# Altium schematic sheet DDR3.SchDoc
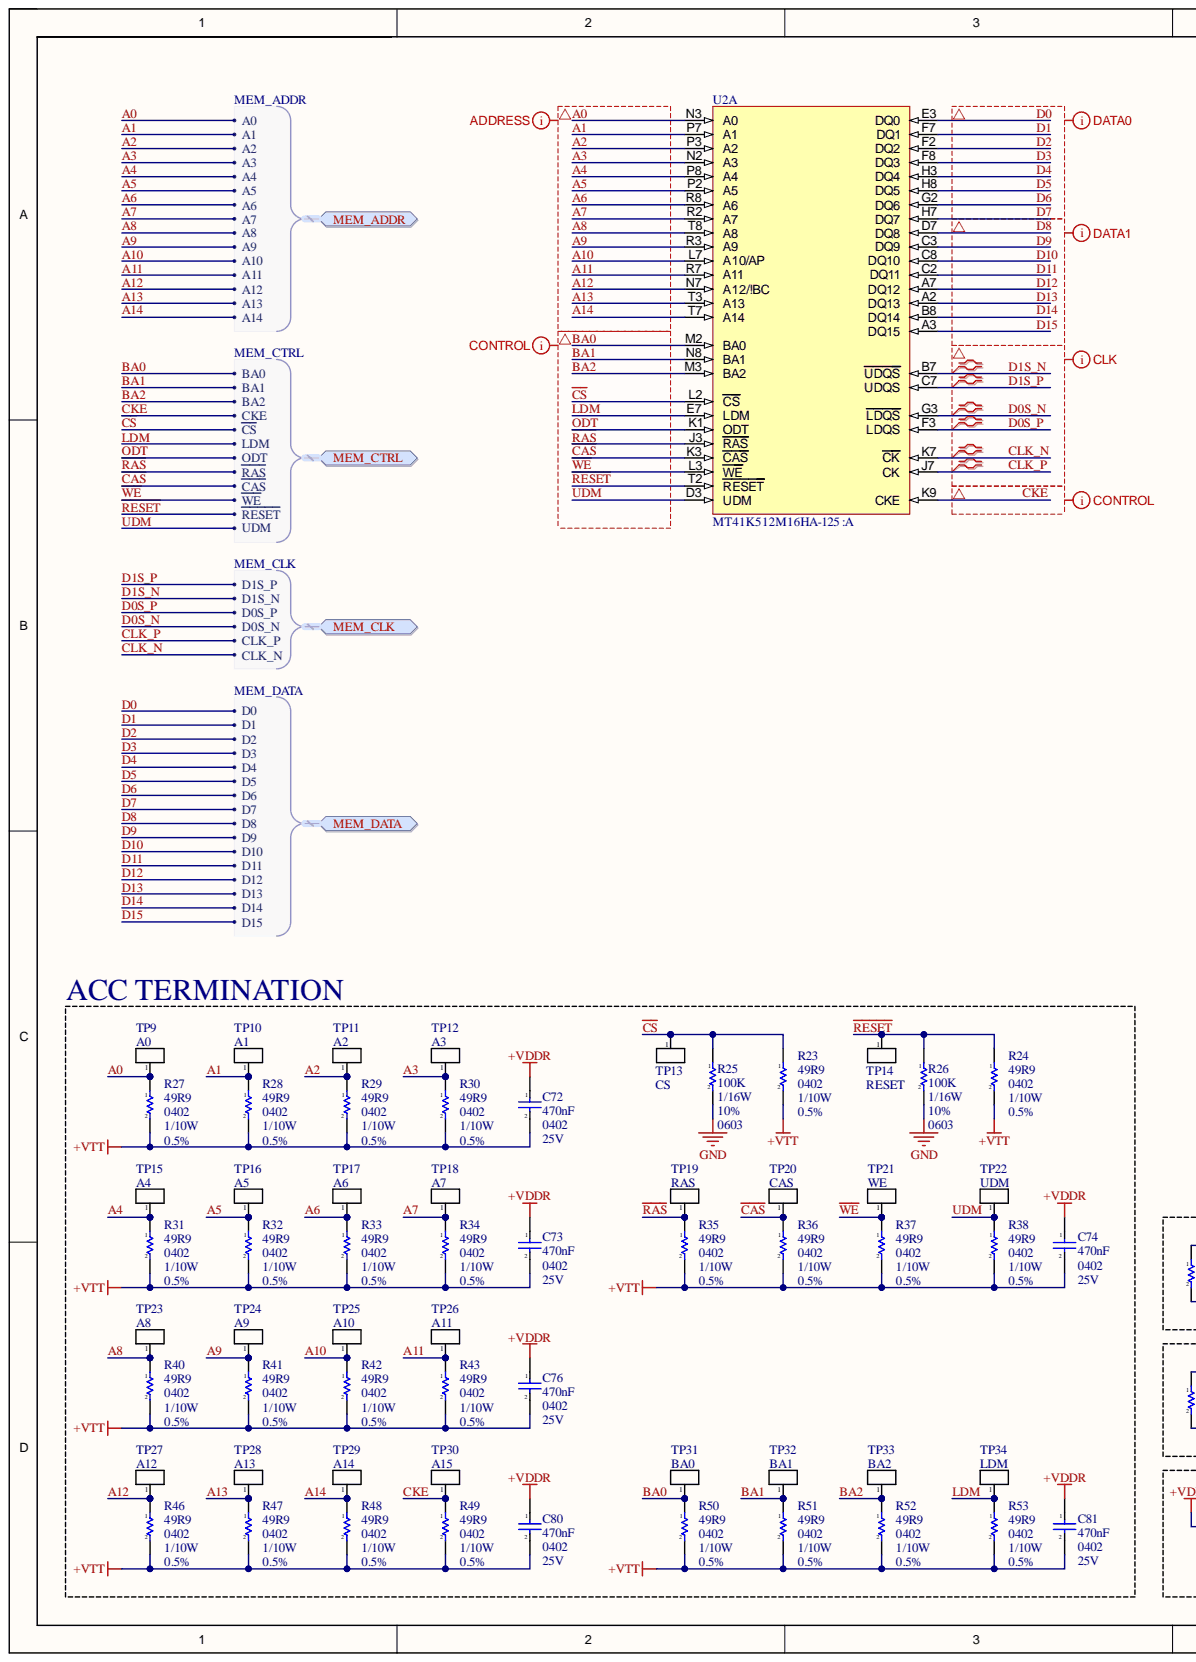
[diagram p0: DDR3.SchDoc, left]
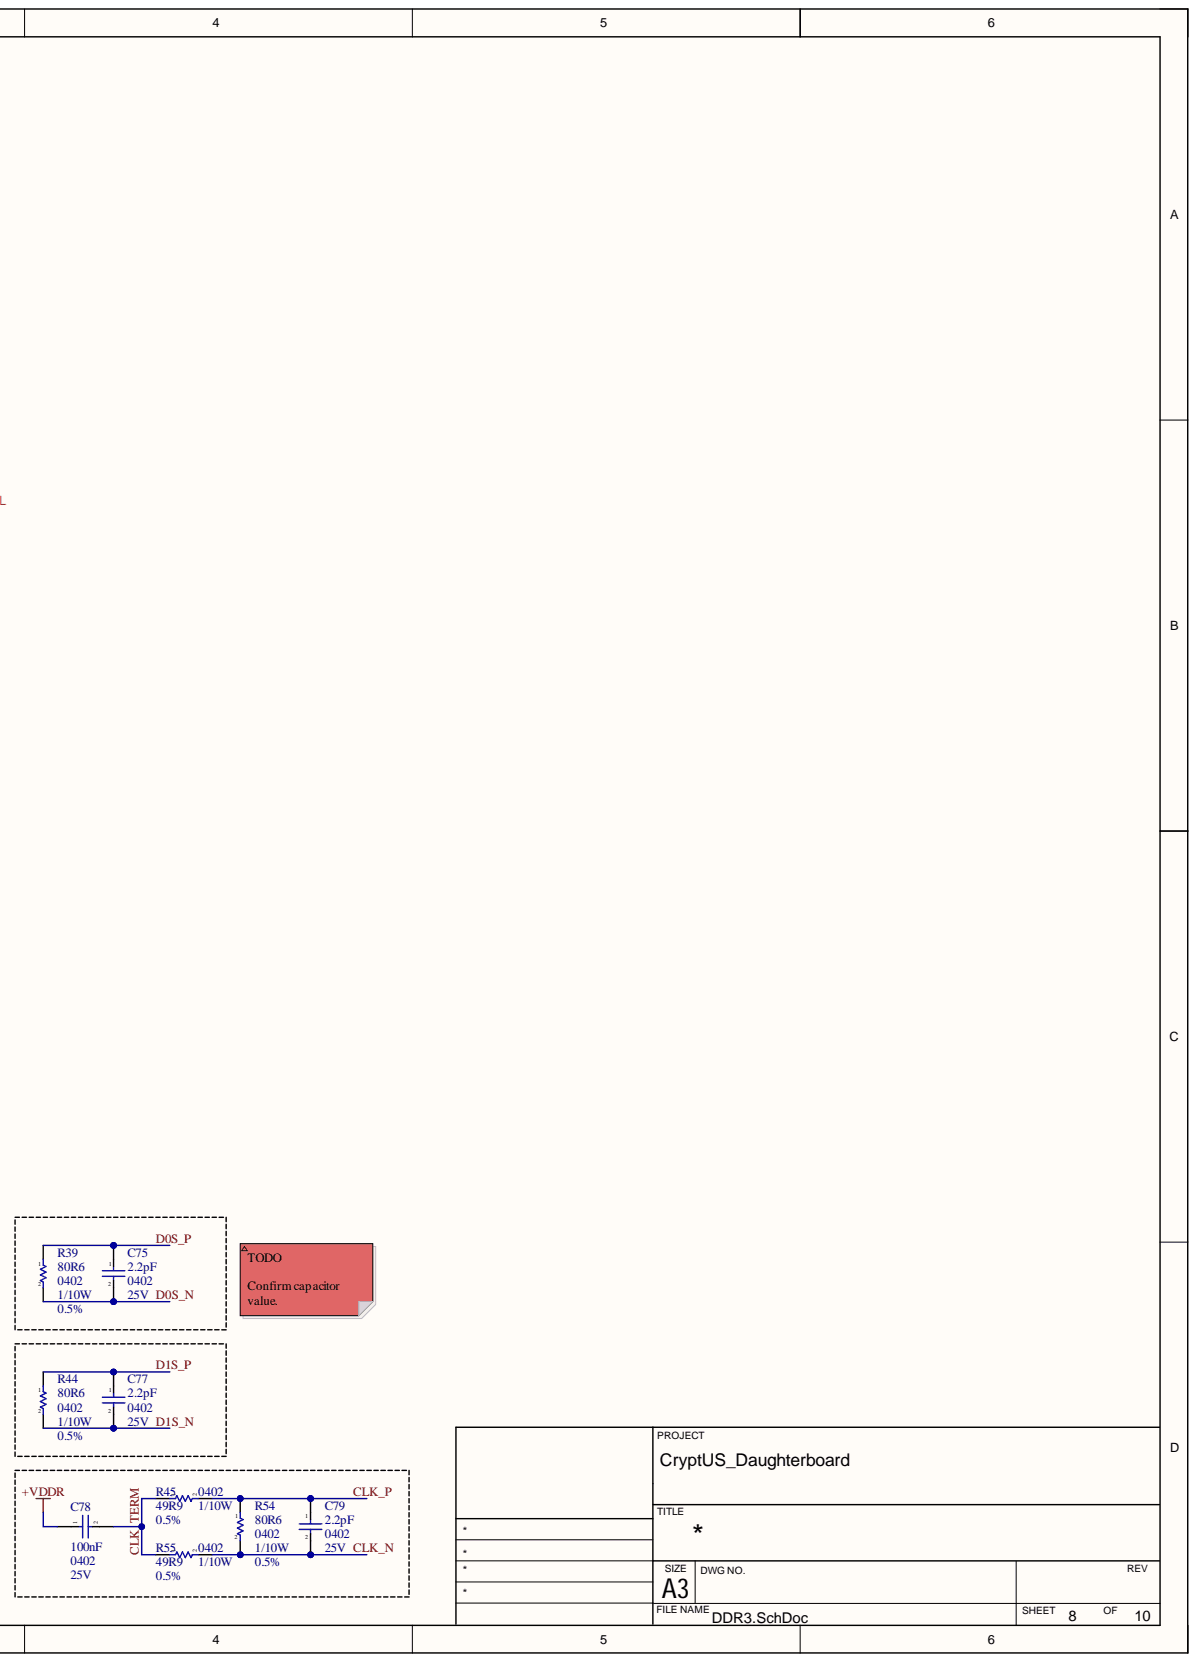
[diagram p1: DDR3.SchDoc, right]

## Parts
C72  470nF  Voltage - Rated: 25V  [0402]
C73  470nF  Voltage - Rated: 25V  [0402]
C74  470nF  Voltage - Rated: 25V  [0402]
C75  2.2pF  Voltage - Rated: 25V  [0402]
C76  470nF  Voltage - Rated: 25V  [0402]
C77  2.2pF  Voltage - Rated: 25V  [0402]
C78  100nF  Voltage - Rated: 25V  [0402]
C79  2.2pF  Voltage - Rated: 25V  [0402]
C80  470nF  Voltage - Rated: 25V  [0402]
C81  470nF  Voltage - Rated: 25V  [0402]
R23  49R9  Package / Case: 0402  Power: 1/10W  Tolerance: 0.5%  [RES_0402]
R24  49R9  Package / Case: 0402  Power: 1/10W  Tolerance: 0.5%  [RES_0402]
R25  100K  Package / Case: 0603  Power: 1/16W  Tolerance: 10%  [RES_0603]
R26  100K  Package / Case: 0603  Power: 1/16W  Tolerance: 10%  [RES_0603]
R27  49R9  Package / Case: 0402  Power: 1/10W  Tolerance: 0.5%  [RES_0402]
R28  49R9  Package / Case: 0402  Power: 1/10W  Tolerance: 0.5%  [RES_0402]
R29  49R9  Package / Case: 0402  Power: 1/10W  Tolerance: 0.5%  [RES_0402]
R30  49R9  Package / Case: 0402  Power: 1/10W  Tolerance: 0.5%  [RES_0402]
R31  49R9  Package / Case: 0402  Power: 1/10W  Tolerance: 0.5%  [RES_0402]
R32  49R9  Package / Case: 0402  Power: 1/10W  Tolerance: 0.5%  [RES_0402]
R33  49R9  Package / Case: 0402  Power: 1/10W  Tolerance: 0.5%  [RES_0402]
R34  49R9  Package / Case: 0402  Power: 1/10W  Tolerance: 0.5%  [RES_0402]
R35  49R9  Package / Case: 0402  Power: 1/10W  Tolerance: 0.5%  [RES_0402]
R36  49R9  Package / Case: 0402  Power: 1/10W  Tolerance: 0.5%  [RES_0402]
R37  49R9  Package / Case: 0402  Power: 1/10W  Tolerance: 0.5%  [RES_0402]
R38  49R9  Package / Case: 0402  Power: 1/10W  Tolerance: 0.5%  [RES_0402]
R39  80R6  Package / Case: 0402  Tolerance: 0.5%  [RES_0402]
R40  49R9  Package / Case: 0402  Power: 1/10W  Tolerance: 0.5%  [RES_0402]
R41  49R9  Package / Case: 0402  Power: 1/10W  Tolerance: 0.5%  [RES_0402]
R42  49R9  Package / Case: 0402  Power: 1/10W  Tolerance: 0.5%  [RES_0402]
R43  49R9  Package / Case: 0402  Power: 1/10W  Tolerance: 0.5%  [RES_0402]
R44  80R6  Package / Case: 0402  Tolerance: 0.5%  [RES_0402]
R45  49R9  Package / Case: 0402  Power: 1/10W  Tolerance: 0.5%  [RES_0402]
R46  49R9  Package / Case: 0402  Power: 1/10W  Tolerance: 0.5%  [RES_0402]
R47  49R9  Package / Case: 0402  Power: 1/10W  Tolerance: 0.5%  [RES_0402]
R48  49R9  Package / Case: 0402  Power: 1/10W  Tolerance: 0.5%  [RES_0402]
R49  49R9  Package / Case: 0402  Power: 1/10W  Tolerance: 0.5%  [RES_0402]
R50  49R9  Package / Case: 0402  Power: 1/10W  Tolerance: 0.5%  [RES_0402]
R51  49R9  Package / Case: 0402  Power: 1/10W  Tolerance: 0.5%  [RES_0402]
R52  49R9  Package / Case: 0402  Power: 1/10W  Tolerance: 0.5%  [RES_0402]
R53  49R9  Package / Case: 0402  Power: 1/10W  Tolerance: 0.5%  [RES_0402]
R54  80R6  Package / Case: 0402  Power: 1/10W  Tolerance: 0.5%  [RES_0402]
R55  49R9  Package / Case: 0402  Power: 1/10W  Tolerance: 0.5%  [RES_0402]
TP9  A0  [TP_PAD_SMALL]
TP10  A1  [TP_PAD_SMALL]
TP11  A2  [TP_PAD_SMALL]
TP12  A3  [TP_PAD_SMALL]
TP13  CS  [TP_PAD_SMALL]
TP14  RESET  [TP_PAD_SMALL]
TP15  A4  [TP_PAD_SMALL]
TP16  A5  [TP_PAD_SMALL]
TP17  A6  [TP_PAD_SMALL]
TP18  A7  [TP_PAD_SMALL]
TP19  RAS  [TP_PAD_SMALL]
TP20  CAS  [TP_PAD_SMALL]
TP21  WE  [TP_PAD_SMALL]
TP22  UDM  [TP_PAD_SMALL]
TP23  A8  [TP_PAD_SMALL]
TP24  A9  [TP_PAD_SMALL]
TP25  A10  [TP_PAD_SMALL]
TP26  A11  [TP_PAD_SMALL]
TP27  A12  [TP_PAD_SMALL]
TP28  A13  [TP_PAD_SMALL]
TP29  A14  [TP_PAD_SMALL]
TP30  A15  [TP_PAD_SMALL]
TP31  BA0  [TP_PAD_SMALL]
TP32  BA1  [TP_PAD_SMALL]
TP33  BA2  [TP_PAD_SMALL]
TP34  LDM  [TP_PAD_SMALL]
U2  MT41K512M16HA-125 :A  [FBGA-96]  (part A)

## Ports
MEM_ADDR, MEM_ADDR.A0, MEM_ADDR.A1, MEM_ADDR.A2, MEM_ADDR.A3, MEM_ADDR.A4, MEM_ADDR.A5, MEM_ADDR.A6, MEM_ADDR.A7, MEM_ADDR.A8, MEM_ADDR.A9, MEM_ADDR.A10, MEM_ADDR.A11, MEM_ADDR.A12, MEM_ADDR.A13, MEM_ADDR.A14, MEM_CLK, MEM_CLK.CLK_N, MEM_CLK.CLK_P, MEM_CLK.D0S_N, MEM_CLK.D0S_P, MEM_CLK.D1S_N, MEM_CLK.D1S_P, MEM_CTRL, MEM_CTRL.BA0, MEM_CTRL.BA1, MEM_CTRL.BA2, MEM_CTRL.CKE, MEM_CTRL.LDM, MEM_CTRL.ODT, MEM_CTRL.UDM, MEM_CTRL~{.CAS}, MEM_CTRL~{.CS}, MEM_CTRL~{.RAS}, MEM_CTRL~{.RESET}, MEM_CTRL~{.WE}, MEM_DATA, MEM_DATA.D0, MEM_DATA.D1, MEM_DATA.D2, MEM_DATA.D3, MEM_DATA.D4, MEM_DATA.D5, MEM_DATA.D6, MEM_DATA.D7, MEM_DATA.D8, MEM_DATA.D9, MEM_DATA.D10, MEM_DATA.D11, MEM_DATA.D12, MEM_DATA.D13, MEM_DATA.D14, MEM_DATA.D15

## Nets
+VDDR: C72.1 C73.1 C74.1 C76.1 C78.1 C80.1 C81.1
+VTT: C72.2 C73.2 C74.2 C76.2 C80.2 C81.2 R23.2 R24.2 R27.2 R28.2 R29.2 R30.2 R31.2 R32.2 R33.2 R34.2 R35.2 R36.2 R37.2 R38.2 R40.2 R41.2 R42.2 R43.2 R46.2 R47.2 R48.2 R49.2 R50.2 R51.2 R52.2 R53.2
GND: R25.2 R26.2
~{CAS}: R36.1 TP20.1 U2.K3(CAS) ; port MEM_CTRL
~{CS}: R23.1 R25.1 TP13.1 U2.L2(CS) ; port MEM_CTRL
~{RAS}: R35.1 TP19.1 U2.J3(RAS) ; port MEM_CTRL
~{RESET}: R24.1 R26.1 TP14.1 U2.T2(RESET) ; port MEM_CTRL
~{WE}: R37.1 TP21.1 U2.L3(WE) ; port MEM_CTRL
A0: R27.1 TP9.1 U2.N3(A0) ; port MEM_ADDR
A1: R28.1 TP10.1 U2.P7(A1) ; port MEM_ADDR
A2: R29.1 TP11.1 U2.P3(A2) ; port MEM_ADDR
A3: R30.1 TP12.1 U2.N2(A3) ; port MEM_ADDR
A4: R31.1 TP15.1 U2.P8(A4) ; port MEM_ADDR
A5: R32.1 TP16.1 U2.P2(A5) ; port MEM_ADDR
A6: R33.1 TP17.1 U2.R8(A6) ; port MEM_ADDR
A7: R34.1 TP18.1 U2.R2(A7) ; port MEM_ADDR
A8: R40.1 TP23.1 U2.T8(A8) ; port MEM_ADDR
A9: R41.1 TP24.1 U2.R3(A9) ; port MEM_ADDR
A10: R42.1 TP25.1 U2.L7(A10/AP) ; port MEM_ADDR
A11: R43.1 TP26.1 U2.R7(A11) ; port MEM_ADDR
A12: R46.1 TP27.1 U2.N7(A12/!BC) ; port MEM_ADDR
A13: R47.1 TP28.1 U2.T3(A13) ; port MEM_ADDR
A14: R48.1 TP29.1 U2.T7(A14) ; port MEM_ADDR
BA0: R50.1 TP31.1 U2.M2(BA0) ; port MEM_CTRL
BA1: R51.1 TP32.1 U2.N8(BA1) ; port MEM_CTRL
BA2: R52.1 TP33.1 U2.M3(BA2) ; port MEM_CTRL
CKE: R49.1 TP30.1 U2.K9(CKE) ; port MEM_CTRL
CLK_N: C79.2 R54.2 R55.2 U2.K7(CK) ; port MEM_CLK
CLK_P: C79.1 R45.2 R54.1 U2.J7(CK) ; port MEM_CLK
CLK_TERM: C78.2 R45.1 R55.1
D0: U2.E3(DQ0) ; port MEM_DATA
D0S_N: C75.2 R39.2 U2.G3(LDQS) ; port MEM_CLK
D0S_P: C75.1 R39.1 U2.F3(LDQS) ; port MEM_CLK
D1: U2.F7(DQ1) ; port MEM_DATA
D1S_N: C77.2 R44.2 U2.B7(UDQS) ; port MEM_CLK
D1S_P: C77.1 R44.1 U2.C7(UDQS) ; port MEM_CLK
D2: U2.F2(DQ2) ; port MEM_DATA
D3: U2.F8(DQ3) ; port MEM_DATA
D4: U2.H3(DQ4) ; port MEM_DATA
D5: U2.H8(DQ5) ; port MEM_DATA
D6: U2.G2(DQ6) ; port MEM_DATA
D7: U2.H7(DQ7) ; port MEM_DATA
D8: U2.D7(DQ8) ; port MEM_DATA
D9: U2.C3(DQ9) ; port MEM_DATA
D10: U2.C8(DQ10) ; port MEM_DATA
D11: U2.C2(DQ11) ; port MEM_DATA
D12: U2.A7(DQ12) ; port MEM_DATA
D13: U2.A2(DQ13) ; port MEM_DATA
D14: U2.B8(DQ14) ; port MEM_DATA
D15: U2.A3(DQ15) ; port MEM_DATA
LDM: R53.1 TP34.1 U2.E7(LDM) ; port MEM_CTRL
ODT: U2.K1(ODT) ; port MEM_CTRL
UDM: R38.1 TP22.1 U2.D3(UDM) ; port MEM_CTRL

## Library data of the parts
C72-C81 = CAP_CER: Generic SMT Ceramic Capacitor; Component Kind: Standard; ComponentLink1Description: Digikey; ComponentLink1URL: [Digikey Link]; ComponentLink2Description: Datasheet; ComponentLink2URL: [Datasheet Link]; Library Name: RaesLib.IntLib
R23-R38 R40-R43 R45-R55 = RESISTOR: Component Kind: Standard; ComponentLink1Description: Digikey; Description: Resistor; Library Name: Symbols.SchLib
R39 R44 = RESISTOR: Power: 1/10W; Component Kind: Standard; ComponentLink1Description: Digikey; Description: Resistor; Library Name: Symbols.SchLib
TP9-TP34 = TESTPOINT: Test Point; Component Kind: Standard; Library Name: Symbols.SchLib
U2 = IC_MT41K512M16HA-125: DRAM 8G 1.35V 512Mx16 800 MHz DDR3 0C-90C; Mfr: Alliance Memory; Data Bus Width: 16 bits; Maximum Clock Frequency: 800MHz; Memory Size: 8Gb; Supply Current (Max): 88mA; Supply Voltage (Max): 1.45V; Supply Voltage (Min): 1.283V; Component Kind: Standard; Memory Organization: 512M x 16; Operating Temperature: 0C - 95C; Package / Case: FBGA-96; Type: SDRAM - DDR3L; Library Name: CryptLib.IntLib
